# Altium schematic sheet 10_HDMI_OUT.SchDoc
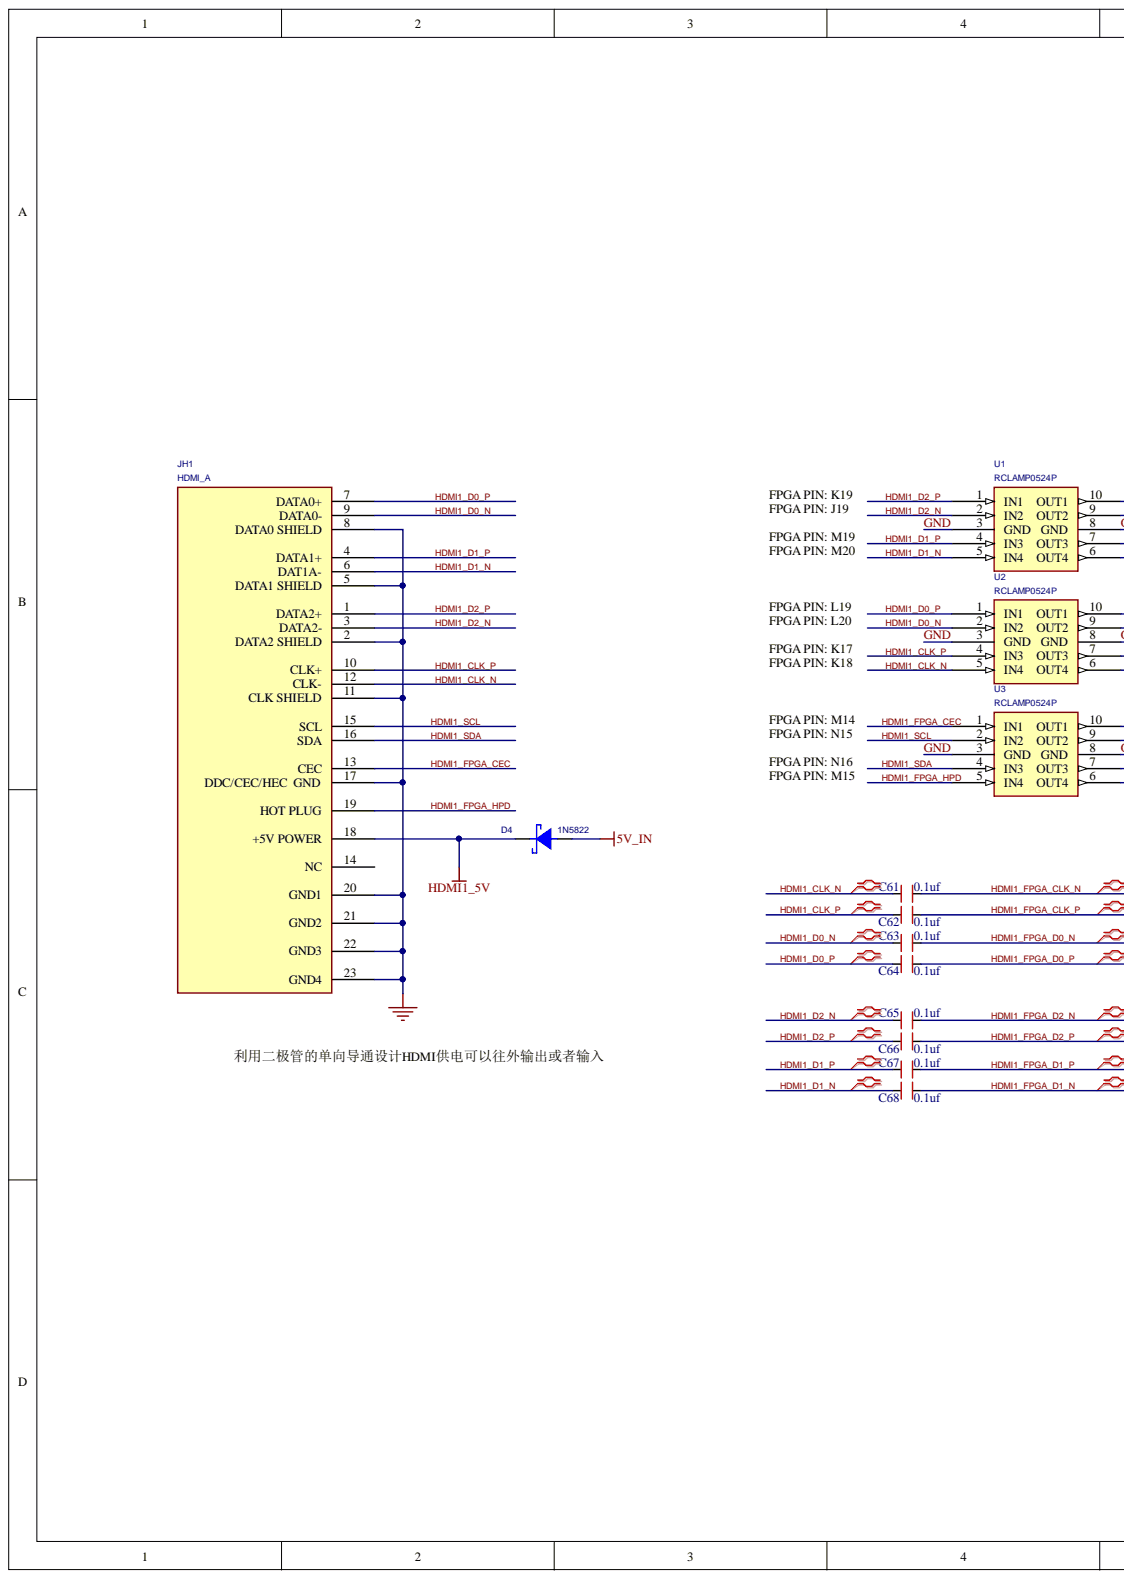
[diagram p0: 10_HDMI_OUT.SchDoc, left]
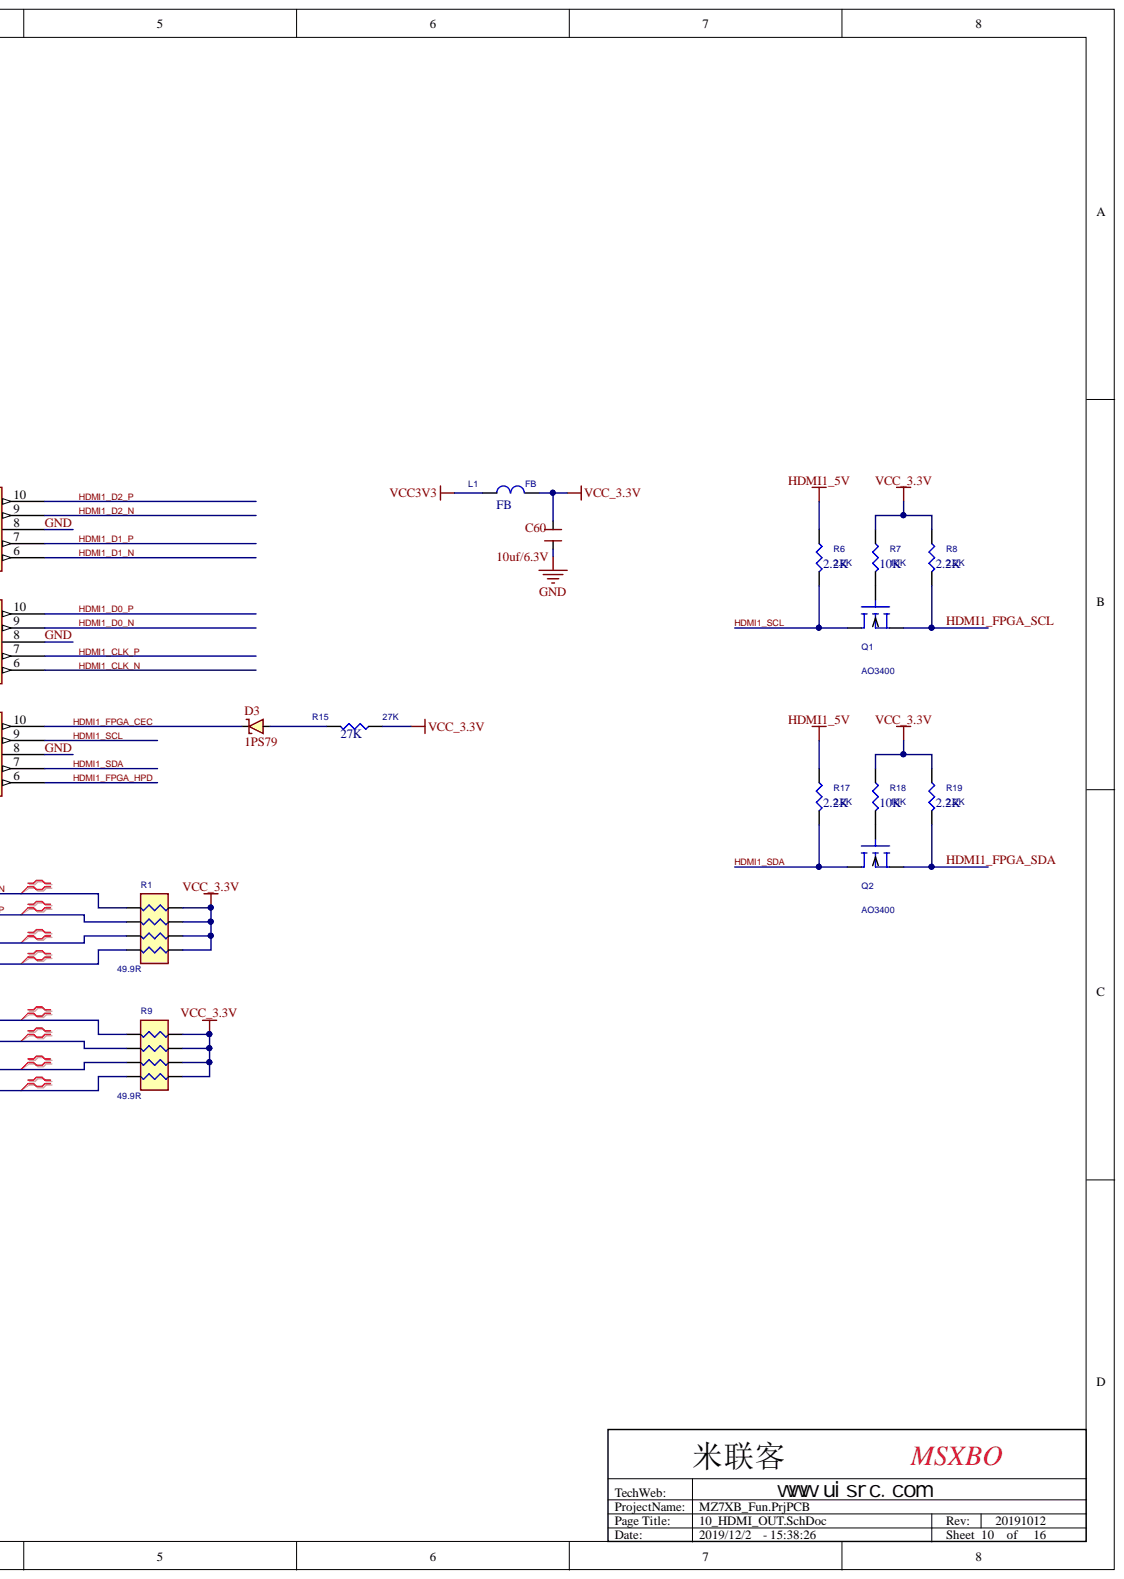
[diagram p1: 10_HDMI_OUT.SchDoc, right]

## Parts
C60  10uf/6.3V  [CAP0805]
C61  0.1uf  [C0402]
C62  0.1uf  [C0402]
C63  0.1uf  [C0402]
C64  0.1uf  [C0402]
C65  0.1uf  [C0402]
C66  0.1uf  [C0402]
C67  0.1uf  [C0402]
C68  0.1uf  [C0402]
D3  1PS79  [SOD-523]
D4  1N5822  [SMA_DO_214AC]
JH1  HDMI_A  [HDMI_1903015]
L1  FB  [CAP0603]
Q1  AO3400  [SOT23]
Q2  AO3400  [SOT23]
R1  49.9R  [RA4_0603]
R6  2.2K  [RES0402]
R7  10K  [RES0402]
R8  2.2K  [RES0402]
R9  49.9R  [RA4_0603]
R15  27K  [RES0402]
R17  2.2K  [RES0402]
R18  10K  [RES0402]
R19  2.2K  [RES0402]
U1  RCLAMP0524P  [SLP2510P8_10]
U2  RCLAMP0524P  [SLP2510P8_10]
U3  RCLAMP0524P  [SLP2510P8_10]

## Nets
5V_IN: D4.1
HDMI1_5V: D4.2 JH1.18(+5V_POWER) R6.2 R17.2
VCC3V3: L1.1
VCC_3.3V: C60.1 L1.2 R1.1 R1.2 R1.3 R1.4 R7.2 R8.2 R9.1 R9.2 R9.3 R9.4 R15.2 R18.2 R19.2
GND: C60.2 JH1.2(DATA2_SHIELD) JH1.5(DATA1_SHIELD) JH1.8(DATA0_SHIELD) JH1.11(CLK_SHIELD) JH1.17(GND) JH1.20(GND1) JH1.21(GND2) JH1.22(GND3) JH1.23(GND4) U1.3(GND) U1.8(GND) U2.3(GND) U2.8(GND) U3.3(GND) U3.8(GND)
HDMI1_CLK_N: C61.1 JH1.12(CLK-) U2.5(IN4) U2.6(OUT4)
HDMI1_CLK_P: C62.1 JH1.10(CLK+) U2.4(IN3) U2.7(OUT3)
HDMI1_D0_N: C63.1 JH1.9(DATA0-) U2.2(IN2) U2.9(OUT2)
HDMI1_D0_P: C64.1 JH1.7(DATA0+) U2.1(IN1) U2.10(OUT1)
HDMI1_D1_N: C68.1 JH1.6(DAT1A-) U1.5(IN4) U1.6(OUT4)
HDMI1_D1_P: C67.1 JH1.4(DATA1+) U1.4(IN3) U1.7(OUT3)
HDMI1_D2_N: C65.1 JH1.3(DATA2-) U1.2(IN2) U1.9(OUT2)
HDMI1_D2_P: C66.1 JH1.1(DATA2+) U1.1(IN1) U1.10(OUT1)
HDMI1_FPGA_CEC: D3.K JH1.13(CEC) U3.1(IN1) U3.10(OUT1)
HDMI1_FPGA_CLK_N: C61.2 R1.8
HDMI1_FPGA_CLK_P: C62.2 R1.7
HDMI1_FPGA_D0_N: C63.2 R1.6
HDMI1_FPGA_D0_P: C64.2 R1.5
HDMI1_FPGA_D1_N: C68.2 R9.5
HDMI1_FPGA_D1_P: C67.2 R9.6
HDMI1_FPGA_D2_N: C65.2 R9.8
HDMI1_FPGA_D2_P: C66.2 R9.7
HDMI1_FPGA_HPD: JH1.19(HOT_PLUG) U3.5(IN4) U3.6(OUT4)
HDMI1_FPGA_SCL: Q1.2 R8.1
HDMI1_FPGA_SDA: Q2.2 R19.1
HDMI1_SCL: JH1.15(SCL) Q1.3 R6.1 U3.2(IN2) U3.9(OUT2)
HDMI1_SDA: JH1.16(SDA) Q2.3 R17.1 U3.4(IN3) U3.7(OUT3)
NetD3_A: D3.A R15.1
NetQ1_1: Q1.1 R7.1
NetQ2_1: Q2.1 R18.1

Not connected: JH1 14(NC)

## Library data of the parts
C60 = CAPACITOR: Generic Capacitor; Value: 4.7uF; Component Kind: Standard; Library Name: Digilent.IntLib
C61-C68 = C0402: Component Kind: Standard
D3 = DIODE-SCH: Schotkey Diode NOT GENERIC; Component Kind: Standard; Library Name: Digilent.IntLib
D4 = 1N5817: Component Kind: Standard
L1 = INDUCTOR1: CURRENT: LMAX; DIELECTRIC: DSMAX; DIST: FLAT; IL1: 0; PSpiceTemplate: L^@REFDES %1 %2 ?TOLERANCE|L^@REFDES| @VALUE ?IC/IC=@IC/ ?TOLERANCE|\n.model l^@REFDES IND L=1 DEV=@TOLERANCE% TC1=@TC1 TC2=@TC2 IL1=@IL1 IL2=@IL2|; Component Kind: Standard; IL2: 0; Simulation: INDUCTOR1; TC1: 0; TC2: 0; Value: FB
Q1 Q2 = AO3400: PSpiceTemplate: X^@REFDES %d %g %s @MODEL; Component Kind: Standard; Simulation: AO3400
R1 R9 = RA-4: Component Kind: Standard
R6-R8 R15 R17-R19 = RES1: DIST: FLAT; MAX_TEMP: RTMAX; POWER: RMAX; PSpiceTemplate: R^@REFDES %1 %2 ?TOLERANCE|R^@REFDES| @VALUE TC=@TC1,@TC2 ?TOLERANCE|\n.model R^@REFDES RES R=1 DEV=@TOLERANCE% TC1=@TC1 TC2=@TC2|; SLOPE: RSMAX; TC1: 0; VOLTAGE: RVMAX; Component Kind: Standard; Simulation: RES1; TC2: 0
  R6 R8 R17 R19: Value: 2.2K
  R7 R18: Value: 10K
  R15: Value: 27K
JH1 = HDMI_A: Component Kind: Standard
U1-U3 = RCLAMP0524P: Component Kind: Standard
